# Altium schematic sheet mbedXdotShield-V_1_2_0_0.SchDoc(mbedXdotShield-V_1_2_0_0)
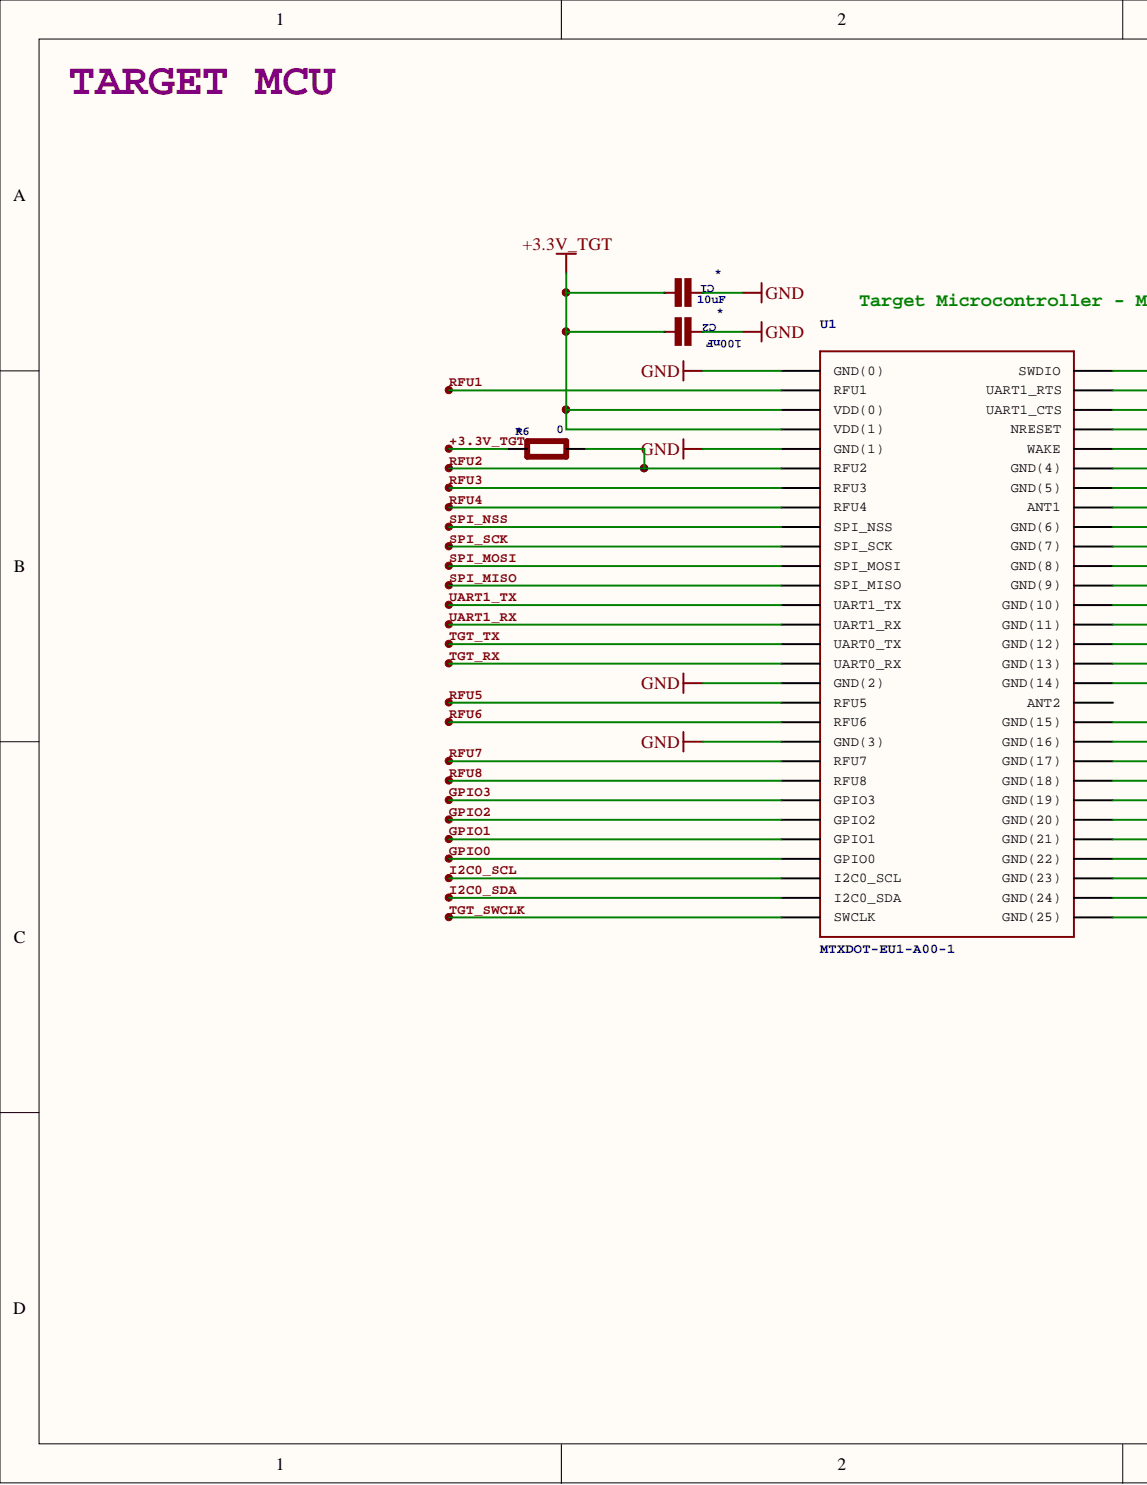
[diagram p0: mbedXdotShield-V_1_2_0_0.SchDoc, left]
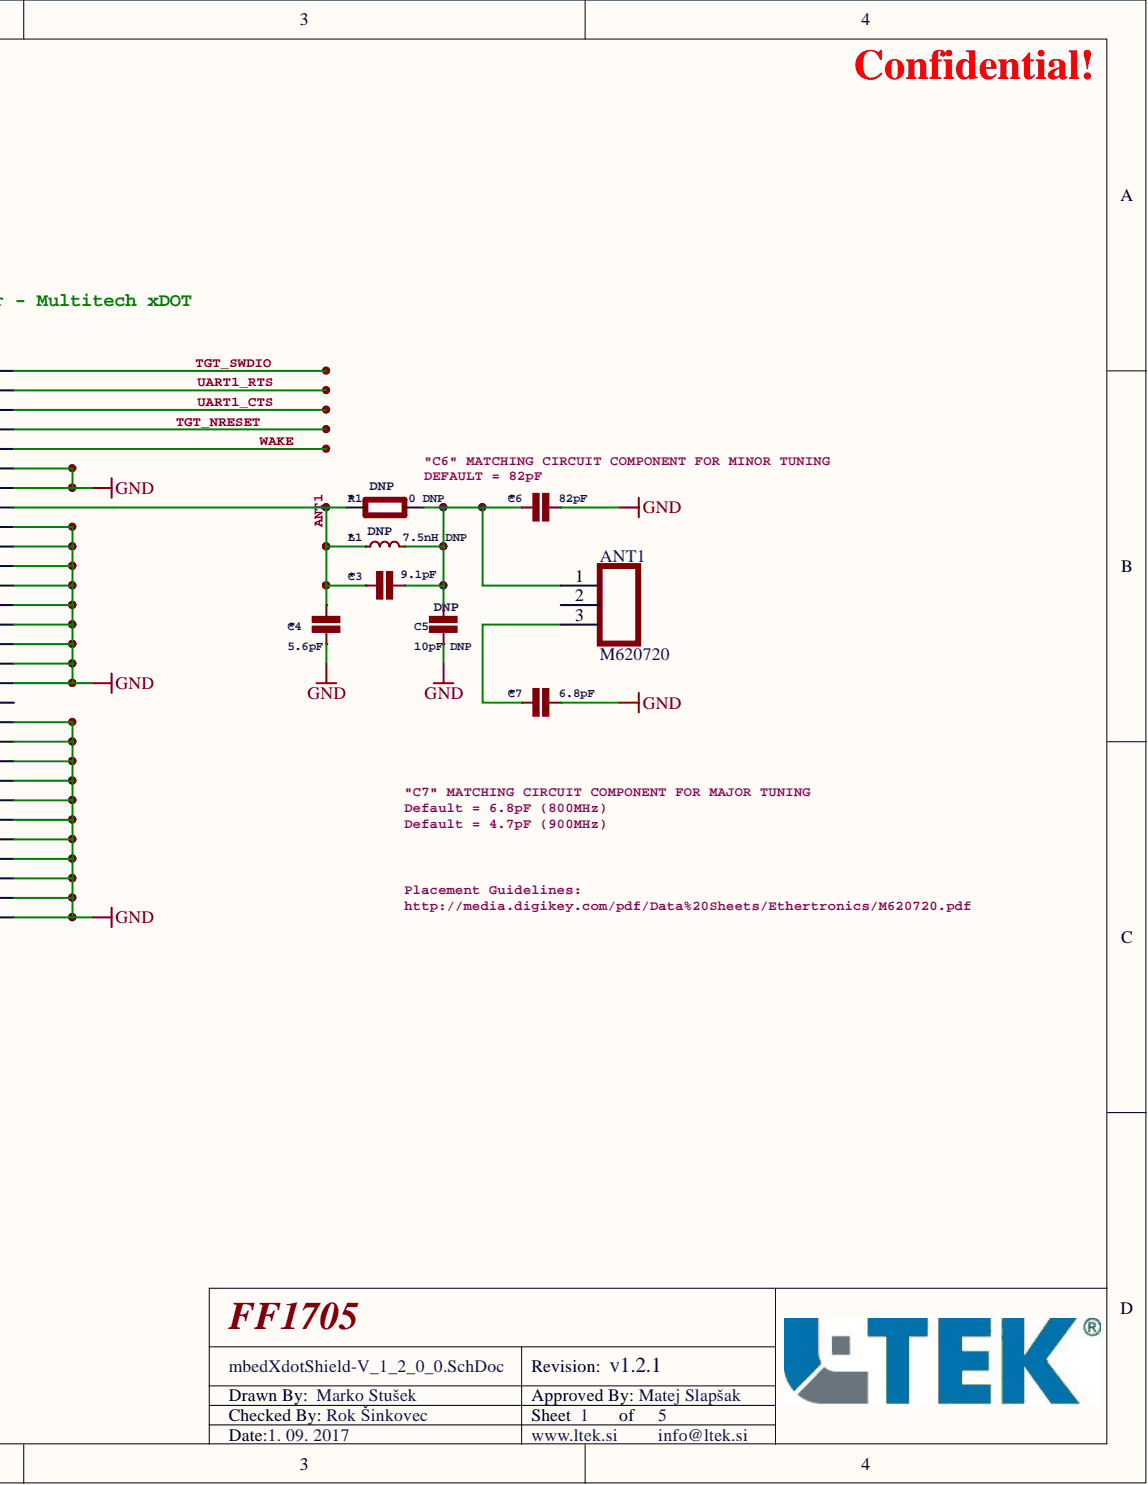
[diagram p1: mbedXdotShield-V_1_2_0_0.SchDoc, right]

## Parts
ANT1  M620720  [M620720_PCB]
C1  10uF  [0603]
C2  100nF  [0603]
C3  9.1pF  [0402]
C4  5.6pF  [0402]
C5  10pF DNP  [0402]
C6  82pF  [0402]
C7  6.8pF  [0402]
L1  7.5nH DNP  [0402]
R1  0 DNP  [0402]
R6  0  [0402]
U1  MTXDOT-EU1-A00-1  [MTXDOT-EU1/NA1-A00-1]

## Nets
GND: C1.2 C2.2 C4.1 C5.1 C6.1 C7.1 U1.P$1(GND(0)) U1.P$5(GND(1)) U1.P$17(GND(2)) U1.P$20(GND(3)) U1.P$35(GND(4)) U1.P$36(GND(5)) U1.P$38(GND(6)) U1.P$39(GND(7)) U1.P$40(GND(8)) U1.P$41(GND(9)) U1.P$42(GND(10)) U1.P$43(GND(11)) U1.P$44(GND(12)) U1.P$45(GND(13)) U1.P$46(GND(14)) U1.P$48(GND(15)) U1.P$49(GND(16)) U1.P$50(GND(17)) U1.P$51(GND(18)) U1.P$52(GND(19)) U1.P$53(GND(20)) U1.P$54(GND(21)) U1.P$55(GND(22)) U1.P$56(GND(23)) U1.P$57(GND(24)) U1.P$58(GND(25))
+3.3V_TGT: C1.1 C2.1 R6.1 U1.P$3(VDD(0)) U1.P$4(VDD(1))
ANT1: C3.1 C4.2 L1.1 R1.1 U1.P$37(ANT1)
GPIO0: U1.P$26(GPIO0)
GPIO1: U1.P$25(GPIO1)
GPIO2: U1.P$24(GPIO2)
GPIO3: U1.P$23(GPIO3)
I2C0_SCL: U1.P$27(I2C0_SCL)
I2C0_SDA: U1.P$28(I2C0_SDA)
RFU1: U1.P$2(RFU1)
RFU2: R6.2 U1.P$6(RFU2)
RFU3: U1.P$7(RFU3)
RFU4: U1.P$8(RFU4)
RFU5: U1.P$18(RFU5)
RFU6: U1.P$19(RFU6)
RFU7: U1.P$21(RFU7)
RFU8: U1.P$22(RFU8)
SPI_MISO: U1.P$12(SPI_MISO)
SPI_MOSI: U1.P$11(SPI_MOSI)
SPI_NSS: U1.P$9(SPI_NSS)
SPI_SCK: U1.P$10(SPI_SCK)
TGT_NRESET: U1.P$33(NRESET)
TGT_RX: U1.P$16(UART0_RX)
TGT_SWCLK: U1.P$29(SWCLK)
TGT_SWDIO: U1.P$30(SWDIO)
TGT_TX: U1.P$15(UART0_TX)
UART1_CTS: U1.P$32(UART1_CTS)
UART1_RTS: U1.P$31(UART1_RTS)
UART1_RX: U1.P$14(UART1_RX)
UART1_TX: U1.P$13(UART1_TX)
WAKE: U1.P$34(WAKE)
NetANT1_1: ANT1.1 C3.2 C5.2 C6.2 L1.2 R1.2
NetANT1_3: ANT1.3 C7.2

Not connected: ANT1 2; U1 P$47(ANT2)

## Library data of the parts
ANT1 = M620720: Ceramic Antennas; Seller/Manufacturer Nr: 939-1066-1-ND/M620720; Seller/Manufacturer: DigiKey/Ethertronics; Comment2: ISM SavviTM Ceramic Antennas; Value: M620720; Component Kind: Standard; Library Name: Ltek_lib_MST.IntLib
C1 C2 = CAPACITOR0603: Capacitor; Component Kind: Standard; Library Name: mbed-HDK-RLCQ.IntLib
C3-C7 = CAPACITOR0402: Capacitor; Component Kind: Standard; Library Name: mbed-HDK-RLCQ.IntLib
L1 = INDUCTOR0402: Inductor; Component Kind: Standard; Library Name: mbed-HDK-RLCQ.IntLib
R1 R6 = RESISTOR0402: Resistor; Component Kind: Standard; Library Name: mbed-HDK-RLCQ.IntLib
U1 = MTXDOT-EU1-A00-1: Multitech xDOT LORA MODULE UFL/TRC 868MHz for Europe; MF: Multi-Tech Systems Inc.; OC-DIGIKEY: 591-1292-ND; MFN: MTXDOT-EU1-A00-1; Component Kind: Standard; Library Name: mbed-HDK-MF-MULTITECH.IntLib
